# Altium schematic sheet aux_power_12V.SchDoc(aux_power_12V)
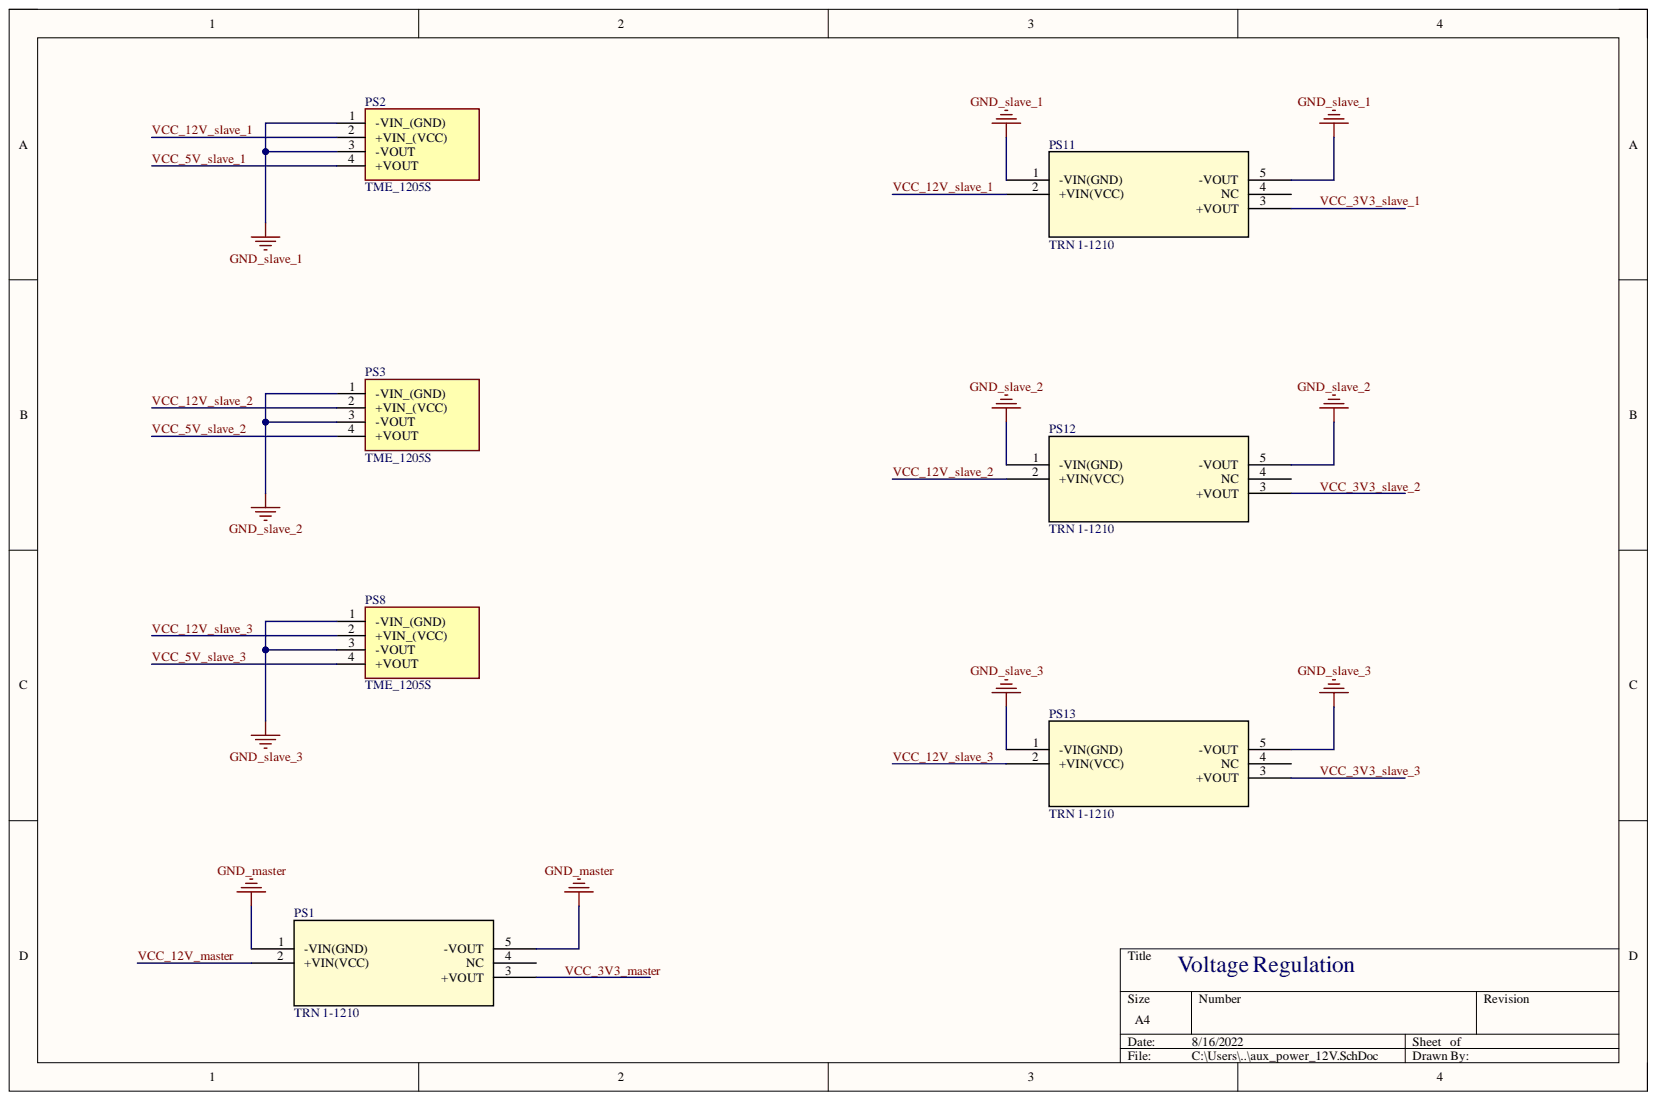
[diagram p0: aux_power_12V.SchDoc]

## Parts
PS1  TRN 1-1210  [TRN1-SINGLE_TRP]
PS2  TME_1205S  [TME0512S]
PS3  TME_1205S  [TME0512S]
PS8  TME_1205S  [TME0512S]
PS11  TRN 1-1210  [TRN1-SINGLE_TRP]
PS12  TRN 1-1210  [TRN1-SINGLE_TRP]
PS13  TRN 1-1210  [TRN1-SINGLE_TRP]

## Nets
GND_master: PS1.1(-VIN(GND)) PS1.5(-VOUT)
GND_slave_1: PS2.1(-VIN_(GND)) PS2.3(-VOUT) PS11.1(-VIN(GND)) PS11.5(-VOUT)
GND_slave_2: PS3.1(-VIN_(GND)) PS3.3(-VOUT) PS12.1(-VIN(GND)) PS12.5(-VOUT)
GND_slave_3: PS8.1(-VIN_(GND)) PS8.3(-VOUT) PS13.1(-VIN(GND)) PS13.5(-VOUT)
VCC_3V3_master: PS1.3(+VOUT)
VCC_3V3_slave_1: PS11.3(+VOUT)
VCC_3V3_slave_2: PS12.3(+VOUT)
VCC_3V3_slave_3: PS13.3(+VOUT)
VCC_5V_slave_1: PS2.4(+VOUT)
VCC_5V_slave_2: PS3.4(+VOUT)
VCC_5V_slave_3: PS8.4(+VOUT)
VCC_12V_master: PS1.2(+VIN(VCC))
VCC_12V_slave_1: PS2.2(+VIN_(VCC)) PS11.2(+VIN(VCC))
VCC_12V_slave_2: PS3.2(+VIN_(VCC)) PS12.2(+VIN(VCC))
VCC_12V_slave_3: PS8.2(+VIN_(VCC)) PS13.2(+VIN(VCC))

Not connected: PS1 4(NC); PS11 4(NC); PS12 4(NC); PS13 4(NC)

## Library data of the parts
PS1 PS11-PS13 = TRN 1-1210: No Description Available; Mfr_Name: Traco Power; Component Kind: Standard; Digi-Key_Part_Number_1: TRN1-1210-ND; Library Name: TRN1-1210.SchLib; Manufacturer_Part_Number: TRN 1-1210; RefDes: RefDes; Type: DEV; Copyright: Copyright (C) 2022 Ultra Librarian. All rights reserved.
PS2 PS3 PS8 = TME_1205S: Power Supply; Manufacturer_Name: Traco Power; Manufacturer_Part_Number: TME 1205S; Component Kind: Standard; Datasheet Link: https://tracopower.com/tme-datasheet/; Height: 10.45mm
